# Altium schematic sheet Sheet1.SchDoc(Sheet1)
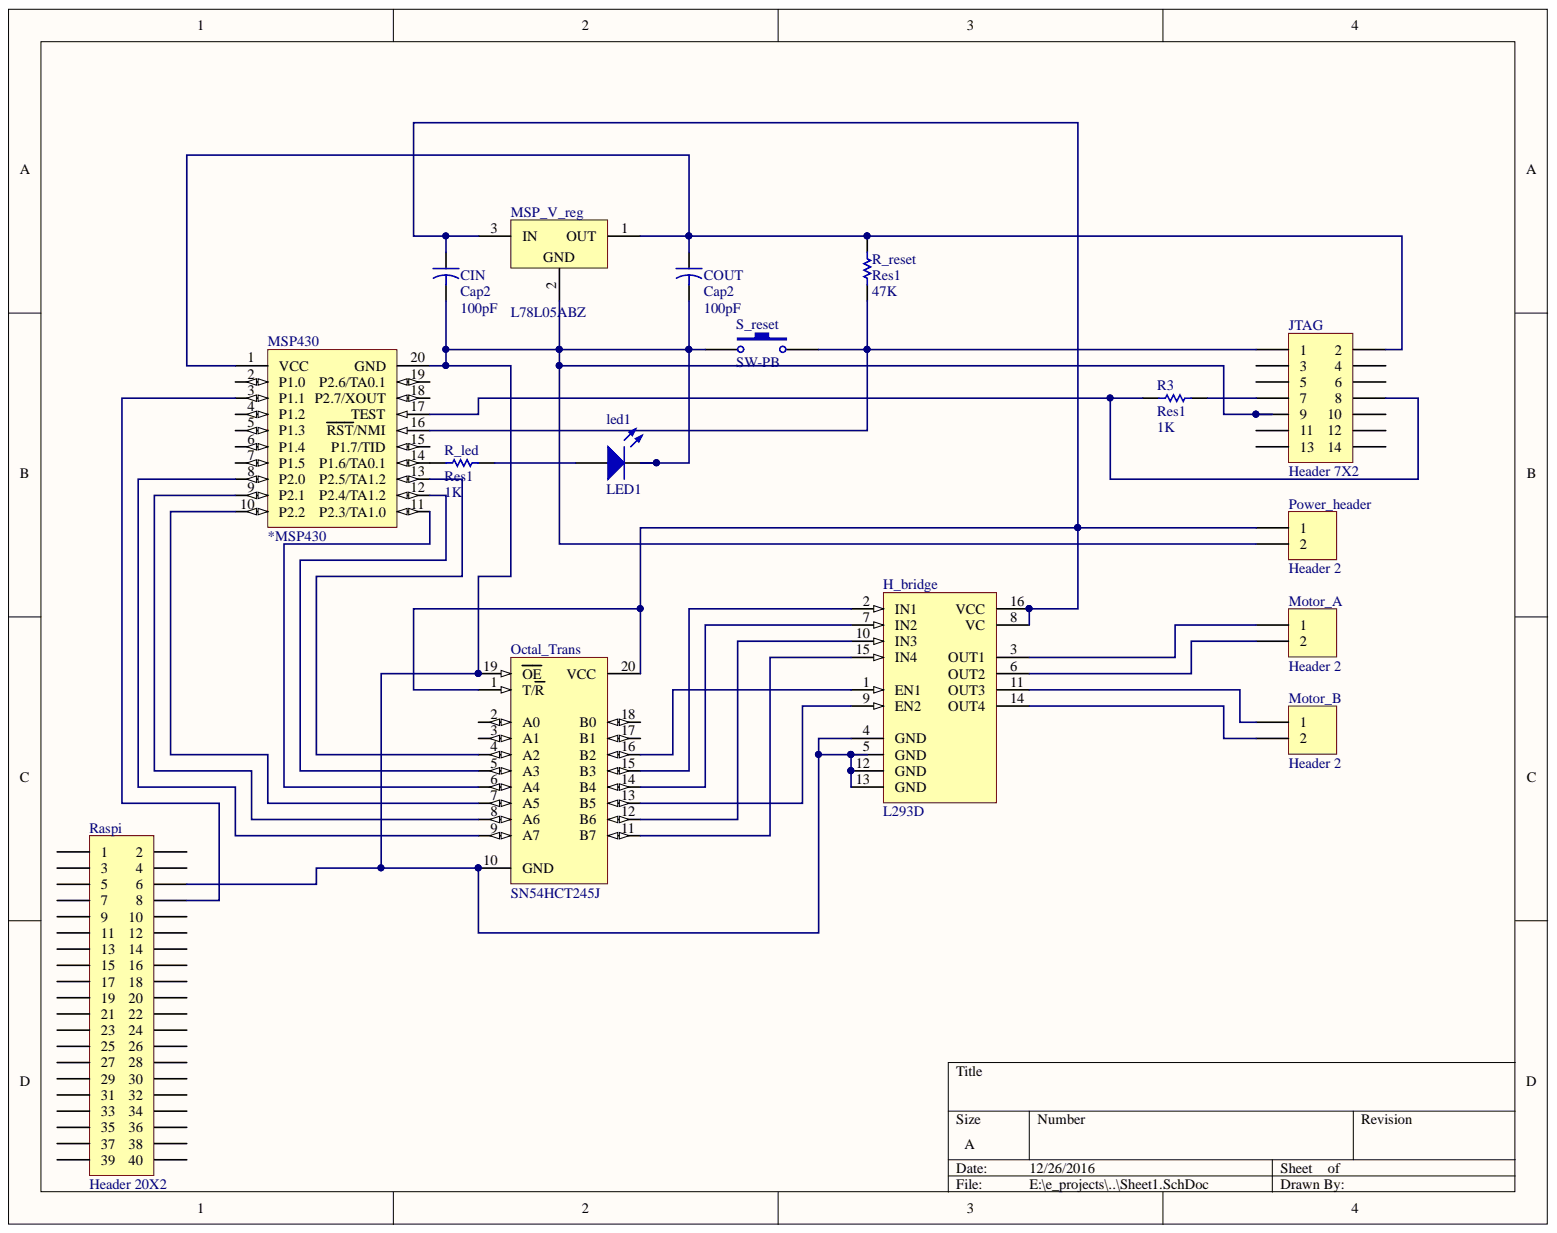
[diagram p0: Sheet1.SchDoc]

## Parts
CIN  Cap2  value 100pF  [CAPR5-4X5]
COUT  Cap2  value 100pF  [CAPR5-4X5]
H_bridge  L293D  [DIP16]
JTAG  Header 7X2  [HDR2X7]
led1  LED1  [LED-1]
Motor_A  Header 2  [HDR1X2]
Motor_B  Header 2  [HDR1X2]
MSP430  *MSP430  [MSP430]
MSP_V_reg  L78L05ABZ  [TO92]
Octal_Trans  SN54HCT245J  [J020]
Power_header  Header 2  [HDR1X2]
R3  Res1  value 1K  [AXIAL-0.3]
R_led  Res1  value 1K  [AXIAL-0.3]
R_reset  Res1  value 47K  [AXIAL-0.3]
Raspi  Header 20X2  [HDR2X20]
S_reset  SW-PB  [SPST-2]

## Nets
NetCIN_1: CIN.1 H_bridge.8(VC) H_bridge.16(VCC) MSP_V_reg.3 Octal_Trans.1(T/R) Octal_Trans.20(VCC) Power_header.1
NetCIN_2: CIN.2 COUT.2 H_bridge.4(GND) H_bridge.5(GND) H_bridge.12(GND) H_bridge.13(GND) JTAG.9 led1.2 MSP430.20(GND) MSP_V_reg.2 Octal_Trans.10(GND) Octal_Trans.19(OE) Power_header.2 Raspi.6 S_reset.1
NetCOUT_1: COUT.1 JTAG.2 MSP430.1(VCC) MSP_V_reg.1 R_reset.1
NetH_bridge_1: H_bridge.1(EN1) Octal_Trans.16(B2)
NetH_bridge_2: H_bridge.2(IN1) Octal_Trans.15(B3)
NetH_bridge_3: H_bridge.3(OUT1) Motor_A.1
NetH_bridge_6: H_bridge.6(OUT2) Motor_A.2
NetH_bridge_7: H_bridge.7(IN2) Octal_Trans.14(B4)
NetH_bridge_9: H_bridge.9(EN2) Octal_Trans.13(B5)
NetH_bridge_10: H_bridge.10(IN3) Octal_Trans.12(B6)
NetH_bridge_11: H_bridge.11(OUT3) Motor_B.1
NetH_bridge_14: H_bridge.14(OUT4) Motor_B.2
NetH_bridge_15: H_bridge.15(IN4) Octal_Trans.11(B7)
NetJTAG_1: JTAG.1 MSP430.16(RST/NMI) R_reset.2 S_reset.2
NetJTAG_7: JTAG.7 R3.2
NetJTAG_8: JTAG.8 MSP430.17(TEST) R3.1
Netled1_1: led1.1 R_led.2
NetMSP430_3: MSP430.3(P1.1) Raspi.8
NetMSP430_8: MSP430.8(P2.0) Octal_Trans.9(A7)
NetMSP430_9: MSP430.9(P2.1) Octal_Trans.8(A6)
NetMSP430_10: MSP430.10(P2.2) Octal_Trans.7(A5)
NetMSP430_11: MSP430.11(P2.3/TA1.0) Octal_Trans.6(A4)
NetMSP430_12: MSP430.12(P2.4/TA1.2) Octal_Trans.5(A3)
NetMSP430_13: MSP430.13(P2.5/TA1.2) Octal_Trans.4(A2)
NetMSP430_14: MSP430.14(P1.6/TA0.1) R_led.1

Not connected: JTAG 3 4 5 6 10 11 12 13 14; MSP430 2(P1.0) 4(P1.2) 5(P1.3) 6(P1.4) 7(P1.5) 15(P1.7/TID) 18(P2.7/XOUT) 19(P2.6/TA0.1); Octal_Trans 2(A0) 3(A1) 17(B1) 18(B0); Raspi 1 2 3 4 5 7 9 10 11 12 13 14 15 16 17 18 19 20 21 22 23 24 25 26 27 28 29 30 31 32 33 34 35 36 37 38 39 40

## Library data of the parts
CIN COUT = Cap2: Capacitor; Simulation: CAP; Signal Integrity: Cap; Published: 23-Sep-2002; PackageReference: CAPR5-4X5; PackageDescription: Capacitor; 2 Leads; Library Name: Miscellaneous Devices.IntLib; Component Kind: Standard; Publisher: Altium Limited
H_bridge = L293D: Push-Pull Four Channel Driver With Diode; Published: 8-Jun-2000; PCB3D: DIP16; PackageVersion: Apr-1996; PackageReference: DIP16; PackageDescription: DIP; 16 Leads; Row Spacing 7.62 mm; Pitch 2.54 mm; Library Name: ST Interface DC & Stepper Motor Controller.IntLib; LatestRevisionNote: Stylized 3D Model Added.; LatestRevisionDate: 06-Jun-2005; DatasheetVersion: Jun-1996; ComponentLink2URL: http://www.st.com/stonline/products/literature/ds/1330/l293d.pdf; ComponentLink2Description: Datasheet; ComponentLink1URL: http://www.st.com/; ComponentLink1Description: Manufacturer Link; Component Kind: Standard; Publisher: Altium Limited
JTAG = Header 7X2: Header, 7-Pin, Dual row; Signal Integrity: Connector; Library Name: Miscellaneous Connectors.IntLib; LatestRevisionNote: Re-released for DXP Platform.; LatestRevisionDate: 17-Jul-2002; Component Kind: Standard; Publisher: Altium Limited
led1 = LED1: Typical RED GaAs LED; Simulation: LED1; Published: 8-Jun-2000; PackageReference: LED-1; PackageDescription: LED; 2 Leads; Library Name: Miscellaneous Devices.IntLib; LatestRevisionNote: Mech Layer 1 removed.; LatestRevisionDate: 08-Jul-2005; Component Kind: Standard; Publisher: Altium Limited
Motor_A Motor_B Power_header = Header 2: Header, 2-Pin; Signal Integrity: Connector; Library Name: Miscellaneous Connectors.IntLib; LatestRevisionNote: Re-released for DXP Platform.; LatestRevisionDate: 17-Jul-2002; Component Kind: Standard; Publisher: Altium Limited
MSP430 = MSP430G2553: Library Name: MSP430G2553.SchLib; Component Kind: Standard
MSP_V_reg = L78L05ABZ: Positive Voltage Regulator; Published: 24-Aug-2001; PackageReference: TO92; PackageDescription: TO, Flat Index; 3 In-Line, Axial Leads; Body Dia. 4.8mm; Leads 0.51 x 0.51 mm (max); Library Name: ST Power Mgt Voltage Regulator.IntLib; LatestRevisionNote: Re-released for DXP Platform.; LatestRevisionDate: 17-Jul-2002; DatasheetVersion: Feb-1999; ComponentLink1URL: http://www.st.com/; ComponentLink1Description: Manufacturer Link; Component Kind: Standard; Publisher: Altium Limited
Octal_Trans = SN54HCT245J: Octal Bus Transceiver with 3-State Outputs; Signal Integrity: SN54HCT245J; Published: 8-Jun-2000; PCB3D: J020; PackageVersion: Jun-1999; PackageReference: J020; PackageDescription: DIP; 20 Leads; Row Spacing 7.62 mm; Pitch 2.54 mm; Library Name: TI Interface 8-Bit Line Transceiver.IntLib; LatestRevisionNote: Stylized 3D Model Added.; LatestRevisionDate: 04-Mar-2005; DatasheetVersion: May-1997; ComponentLink2URL: http://www-s.ti.com/sc/ds/sn74hct245.pdf; ComponentLink2Description: Datasheet; ComponentLink1URL: http://www.ti.com/; ComponentLink1Description: Manufacturer Link; Component Kind: Standard; Publisher: Altium Limited
R3 R_reset = Res1: Resistor; Simulation: RESISTOR; Signal Integrity: Res; Published: 8-Jun-2000; PackageReference: AXIAL-0.3; PackageDescription: Axial Device, Thru-Hole; 2 Leads; 0.3 in Pin Spacing; Library Name: Miscellaneous Devices.IntLib; LatestRevisionNote: Re-released for DXP Platform.; LatestRevisionDate: 17-Jul-2002; Component Kind: Standard; Publisher: Altium Limited
R_led = Res1: Resistor; Value: 1K; Simulation: RESISTOR; Signal Integrity: Res; Published: 8-Jun-2000; PackageReference: AXIAL-0.3; PackageDescription: Axial Device, Thru-Hole; 2 Leads; 0.3 in Pin Spacing; Library Name: Miscellaneous Devices.IntLib; LatestRevisionNote: Re-released for DXP Platform.; LatestRevisionDate: 17-Jul-2002; Component Kind: Standard; Publisher: Altium Limited
Raspi = Header 20X2: Header, 20-Pin, Dual row; Signal Integrity: Connector; Library Name: Miscellaneous Connectors.IntLib; LatestRevisionNote: Re-released for DXP Platform.; LatestRevisionDate: 17-Jul-2002; Component Kind: Standard; Publisher: Altium Limited
S_reset = SW-PB: Switch; Published: 24-Mar-1999; PackageReference: SPST-2; PackageDescription: Switch; 2 Leads; Library Name: Miscellaneous Devices.IntLib; LatestRevisionNote: Re-released for DXP Platform.; LatestRevisionDate: 17-Jul-2002; Component Kind: Standard; Publisher: Altium Limited
